# Altium schematic sheet Connectors.SchDoc(Connectors)
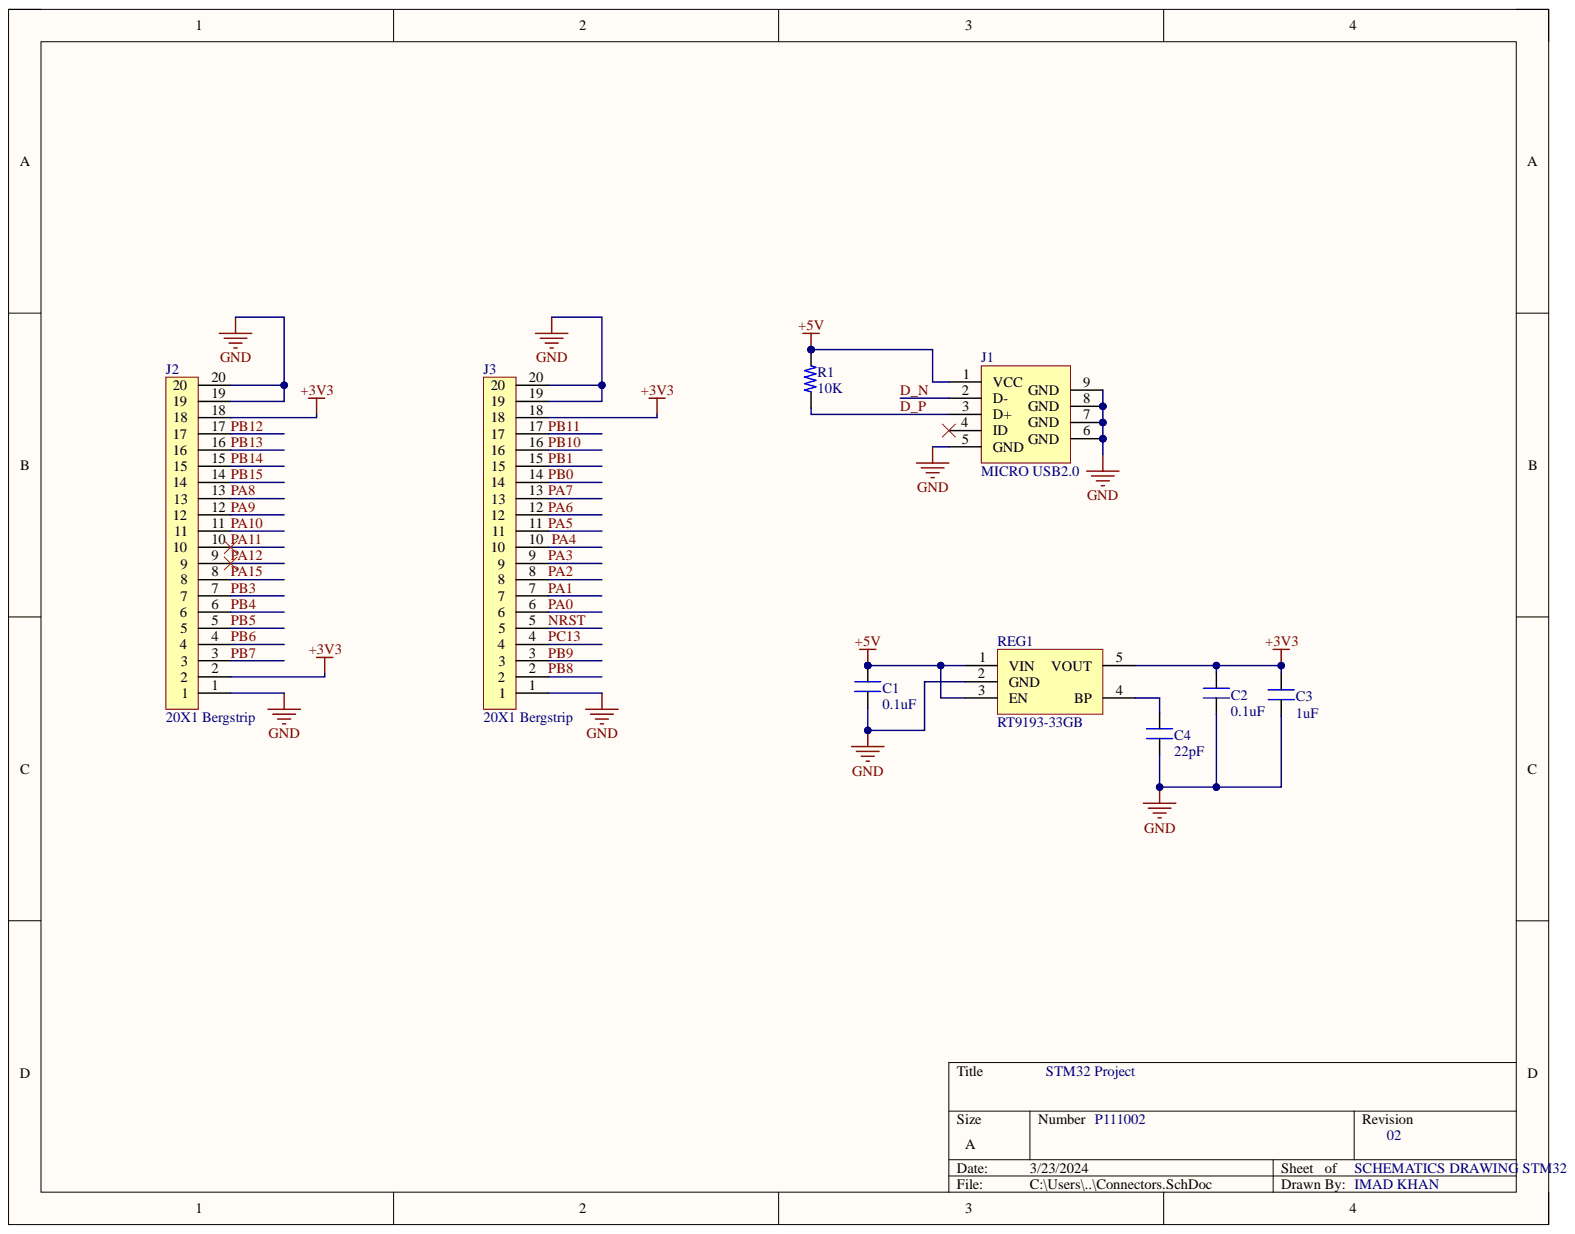
[diagram p0: Connectors.SchDoc]

## Parts
C1  0.1uF  [CAP_0805]
C2  0.1uF  [CAP_0805]
C3  1uF  [CAP_0805]
C4  22pF  [CAP_0805]
J1  MICRO USB2.0  [CON_10118192-0001LF_SMD]
J2  20X1 Bergstrip  [CON20_100_40D72_TH_ST]
J3  20X1 Bergstrip  [CON20_100_40D72_TH_ST]
R1  10K  [RES_0805]
REG1  RT9193-33GB  [SOT23-5]

## Nets
+3V3: C2.2 C3.2 J2.2 J2.18 J3.18 REG1.5(VOUT)
+5V: C1.2 J1.1(VCC) R1.2 REG1.1(VIN) REG1.3(EN)
GND: C1.1 C2.1 C3.1 C4.1 J1.5(GND) J1.6(GND) J1.7(GND) J1.8(GND) J1.9(GND) J2.1 J2.19 J2.20 J3.1 J3.19 J3.20 REG1.2(GND)
D_N: J1.2(D-)
D_P: J1.3(D+) R1.1
NRST: J3.5
PA0: J3.6
PA1: J3.7
PA2: J3.8
PA3: J3.9
PA4: J3.10
PA5: J3.11
PA6: J3.12
PA7: J3.13
PA8: J2.13
PA9: J2.12
PA10: J2.11
PA11: J2.10
PA12: J2.9
PA15: J2.8
PB0: J3.14
PB1: J3.15
PB3: J2.7
PB4: J2.6
PB5: J2.5
PB6: J2.4
PB7: J2.3
PB8: J3.2
PB9: J3.3
PB10: J3.16
PB11: J3.17
PB12: J2.17
PB13: J2.16
PB14: J2.15
PB15: J2.14
PC13: J3.4
NetC4_2: C4.2 REG1.4(BP)

Not connected: J1 4(ID)

## Library data of the parts
C1 C2 = CAP_0.1uF-50V-5%-0805: 0.1µF ±5% 50V Ceramic Capacitor X7R 0805 (2012 Metric); Manufacturer: KEMET; Manufacturing Part Number: C0805C104J5RACTU; Case/Package: 0805 (2012 Metric); Component Kind: Standard; Library Name: STM_SCH_LIB.SchLib; Supplier Part Number-1: 399-1171-1-ND; Supplier-1: Digikey
C3 = CAP_1uF-50V-5%-0805: 1µF ±5% 50V Ceramic Capacitor X7R 0805 (2012 Metric); Manufacturer: Yageo; Manufacturing Part Number: CC0805JKX7R9BB105; Case/Package: 0805 (2012 Metric); Component Kind: Standard; Library Name: STM_SCH_LIB.SchLib; Supplier Part Number-1: 311-3498-1-ND; Supplier-1: Digikey
C4 = CAP_22pF-50V-5%-0805: 22pF ±5% 50V Ceramic Capacitor C0G, NP0 0805 (2012 Metric); Manufacturer: KEMET; Manufacturer Part Number: C0805C220J5GACTU; Case/Package: 0805; Component Kind: Standard; Library Name: STM_SCH_LIB.SchLib; Supplier Part Number-1: 399-1113-1-ND; Supplier-1: Digikey
J1 = CON-USB_10118192-0002LF-SMD: USB - micro B USB 2.0 Receptacle Connector 5 Position Surface Mount, Right Angle; Manufacturer: Amphenol ICC (FCI); Case/Package: SMD; Component Kind: Standard; Library Name: STM_SCH_LIB.SchLib; Manufacturing Part Number: 10118192-0002LF; Supplier Part Number-1: 609-5379-1-ND; Supplier-1: Digikey
J2 J3 = CON-BS-PLG_20X1-100-TH-ST: 20 pin, 1 row, 2.54mm pitch bergstrip; Manufacturer: NA; Case/Package: TH; Component Kind: Standard; Library Name: STM_SCH_LIB.SchLib; Manufacturing Part Number: NA; Supplier Part Number-1: NA; Supplier-1: NA
R1 = RES_10K-0.125W-1%-0805: 10 kOhms ±1% 0.125W, 1/8W Chip Resistor 0805 (2012 Metric) Moisture Resistant Thick Film; Manufacturer: Yageo; Manufacturing Part Number: RC0805FR-0710KL; Case/Package: 0805; Component Kind: Standard; Library Name: STM_SCH_LIB.SchLib; Supplier Part Number-1: 311-10.0KCRCT-ND; Supplier-1: Digikey
REG1 = REG_3.3V-5.5V-0.3A-SOT-23-5: Linear Voltage Regulator IC 1 Output 300mA SOT-23-5; Manufacturer: Richtek USA Inc.; Case/ Package: SOT-23-5; Component Kind: Standard; Library Name: STM_SCH_LIB.SchLib; Manufacturer Part Number: RT9193-33GB; Supplier Part Number-1: 1028-1014-1-ND; Supplier-1: Digikey
